# Altium schematic sheet 原理图3.SchDoc(原理图3)
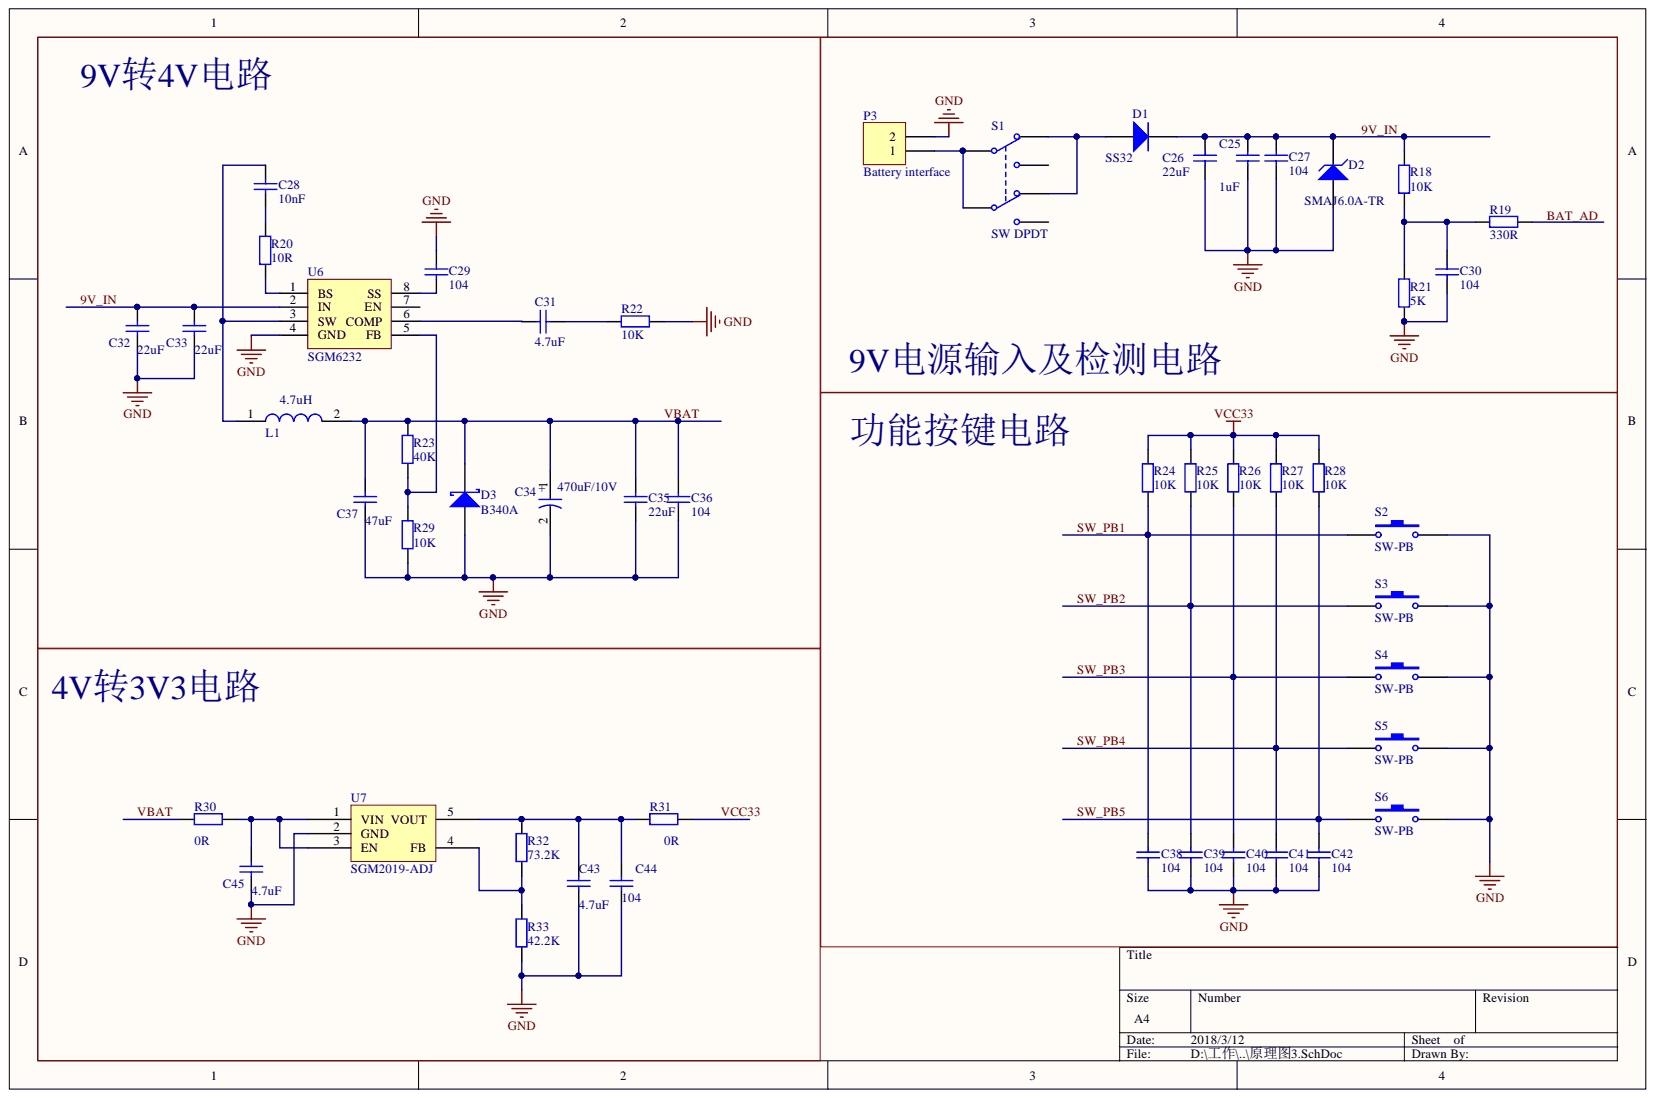
[diagram p0: 原理图3.SchDoc]

## Parts
C25  value 1uF  [0402]  (hidden comment: 22uF)
C26  22uF  [0402]
C27  104  [0402]
C28  10nF  [0402]
C29  104  [0402]
C30  104  [0402]
C31  4.7uF  [0402]
C32  22uF  [0402]
C33  22uF  [0402]
C34  470uF/10V  [TAN-E(2917)]
C35  22uF  [0402]
C36  104  [0402]
C37  47uF  [0402]
C38  104  [0402]
C39  104  [0402]
C40  104  [0402]
C41  104  [0402]
C42  104  [0402]
C43  4.7uF  [0402]
C44  104  [0402]
C45  4.7uF  [0402]
D1  SS32  [DO-214AB]
D2  SMAJ6.0A-TR  [DO-214AC]
D3  B340A  [sma]
L1  4.7uH  [L1]
P3  Battery interface  [HDR1X2]
R18  10K  [0402]
R19  330R  [0402]
R20  10R  [0402]
R21  5K  [0402]
R22  10K  [0402]
R23  40K  [0402]
R24  10K  [0402]
R25  10K  [0402]
R26  10K  [0402]
R27  10K  [0402]
R28  10K  [0402]
R29  10K  [0402]
R30  0R  [0402]
R31  0R  [0402]
R32  73.2K  [0402]
R33  42.2K  [0402]
S1  SW DPDT  [SW-DPDT]
S2  SW-PB  [SW1-6X6]
S3  SW-PB  [SW1-6X6]
S4  SW-PB  [SW1-6X6]
S5  SW-PB  [SW1-6X6]
S6  SW-PB  [SW1-6X6]
U6  SGM6232  [SOIC-8]
U7  SGM2019-ADJ  [SOT-23-5]

## Nets
GND: C25.1 C26.1 C27.1 C29.2 C30.1 C32.2 C33.2 C34.2 C35.1 C36.1 C37.2 C38.1 C39.1 C40.1 C41.1 C42.1 C43.2 C44.1 C45.2 D2.1 D3.1 P3.2 R21.2 R22.1 R29.1 R33.1 S2.2 S3.2 S4.2 S5.2 S6.2 U6.4(GND) U7.2(GND)
9V_IN: C25.2 C26.2 C27.2 C32.1 C33.1 D1.2 D2.2 R18.1 U6.2(IN)
BAT_AD: R19.2
SW_PB1: C38.2 R24.2 S2.1
SW_PB2: C39.2 R25.2 S3.1
SW_PB3: C40.2 R26.2 S4.1
SW_PB4: C41.2 R27.2 S5.1
SW_PB5: C42.2 R28.2 S6.1
VBAT: C34.1 C35.2 C36.2 C37.1 D3.2 L1.2 R23.2 R30.1
VCC33: R24.1 R25.1 R26.1 R27.1 R28.1 R31.2
NetC28_1: C28.1 L1.1 U6.3(SW)
NetC28_2: C28.2 R20.1
NetC29_1: C29.1 U6.8(SS)
NetC30_2: C30.2 R18.2 R19.1 R21.1
NetC31_1: C31.1 R22.2
NetC31_2: C31.2 U6.6(COMP)
NetC43_1: C43.1 C44.2 R31.1 R32.2 U7.5(VOUT)
NetC45_1: C45.1 R30.2 U7.1(VIN) U7.3(EN)
NetD1_1: D1.1 S1.2 S1.4
NetP3_1: P3.1 S1.1 S1.5
NetR20_2: R20.2 U6.1(BS)
NetR23_1: R23.1 R29.2 U6.5(FB)
NetR32_1: R32.1 R33.2 U7.4(FB)

Not connected: S1 3 6; U6 7(EN)

## Library data of the parts
C25-C33 C35-C43 C45 = 0201: Component Kind: Standard
  C26 C32 C33 C35: Value: 22uF
  C27 C29 C30 C36 C38-C42: Value: 104
  C28: Value: 10nF
  C31 C43 C45: Value: 4.7uF
  C37: Value: 47uF
C34 = 02090003: 贴片钽电容; Component Kind: Standard; Library Name: Capacitor.IntLib
C44 = Cap: Capacitor; Component Kind: Standard; Library Name: Miscellaneous Devices.IntLib; Value: 104
D1 = Diode: 肖特基二极管; Code_JEDEC: DO-214-AB; Component Kind: Standard; LatestRevisionDate: 17-Jul-2002; LatestRevisionNote: Re-released for DXP Platform.; Library Name: Miscellaneous Devices.IntLib; PackageDescription: DO-214-AB/SMC; 2 C-Bend Leads; Body 7.9 x 5.9 mm, inc. leads (LxW); PackageReference: SMC; PackageVersion: Sep-1996; Published: 8-Jun-2000; Publisher: Altium Limited
D2 = D Zener: Zener Diode; Component Kind: Standard; LatestRevisionDate: 17-Jul-2002; LatestRevisionNote: Re-released for DXP Platform.; Library Name: Miscellaneous Devices.IntLib; PackageDescription: Diode, Thru-Hole; 2 Leads; 0.7 in Pin Spacing; PackageReference: DIODE-0.7; Published: 8-Jun-2000; Publisher: Altium Limited
D3 = Diode BAT17: Silicon RF Schottky Diode for Mixer Applications in the VHF/UHF Range; Note: Pin 2 is not connected and not drawn.; Component Kind: Standard; ComponentLink1Description: Manufacturer Link; ComponentLink1URL: http://www.infineon.com/; ComponentLink2Description: Datasheet; ComponentLink2URL: http://www.infineon.com/upload/Document/cmc_upload/documents/009/363/bat17series.pdf; DatasheetVersion: Oct-1999; LatestRevisionDate: 29-May-2009; LatestRevisionNote: IPC-7351 Footprint Added.; Library Name: Miscellaneous Devices.IntLib; PackageDescription: 3-Pin SOT-23 Package 0.95 mm Pitch, 2.5 mm Lead Span; PackageReference: SOT-23; PackageVersion: Sep-2001; Published: 21-Mar-2003; Publisher: Altium Limited
L1 = 11010001: SLF7055T-4R7N3R1-3PF; : 4.7uH; Component Kind: Standard; Library Name: Inductor.IntLib
P3 = Header 2: Header, 2-Pin; Component Kind: Standard; LatestRevisionDate: 17-Jul-2002; LatestRevisionNote: Re-released for DXP Platform.; Library Name: Miscellaneous Connectors.IntLib; Signal Integrity: Connector; Publisher: Altium Limited
R18-R33 = Res2: Resistor; Component Kind: Standard; Library Name: Miscellaneous Devices.IntLib
  R18 R22 R24-R29: Value: 10K
  R19: Value: 330R
  R20: Value: 10R
  R21: Value: 5K
  R23: Value: 40K
  R30 R31: Value: 0R
  R32: Value: 73.2K
  R33: Value: 42.2K
S1 = SW DPDT: Switch; Code_IPC: SOT26A-6; Code_JEITA: SC-74; Component Kind: Standard; LatestRevisionDate: 29-May-2009; LatestRevisionNote: IPC-7351 Footprint Added.; Library Name: Miscellaneous Devices.IntLib; PackageDescription: 6-Pin SOT-23 Package 0.95 mm Pitch, 2.7 mm Lead Span; PackageReference: SOT23-6; PackageVersion: Mar-2001; Published: 24-Mar-1999; Publisher: Altium Limited
S2-S6 = SW-PB: 贴片SW6*6开关; Component Kind: Standard; LatestRevisionDate: 17-Jul-2002; LatestRevisionNote: Re-released for DXP Platform.; Library Name: Miscellaneous Devices.IntLib; PackageDescription: Switch; 2 Leads; PackageReference: SPST-2; Published: 24-Mar-1999; Publisher: Altium Limited
U6 = SGM6232: Component Kind: Standard; Library Name: 参考设计库.SchLib
U7 = SGM2019-ADJ: Component Kind: Standard; Library Name: 参考设计库.SchLib
